# Altium schematic sheet Status_RGB.SchDoc
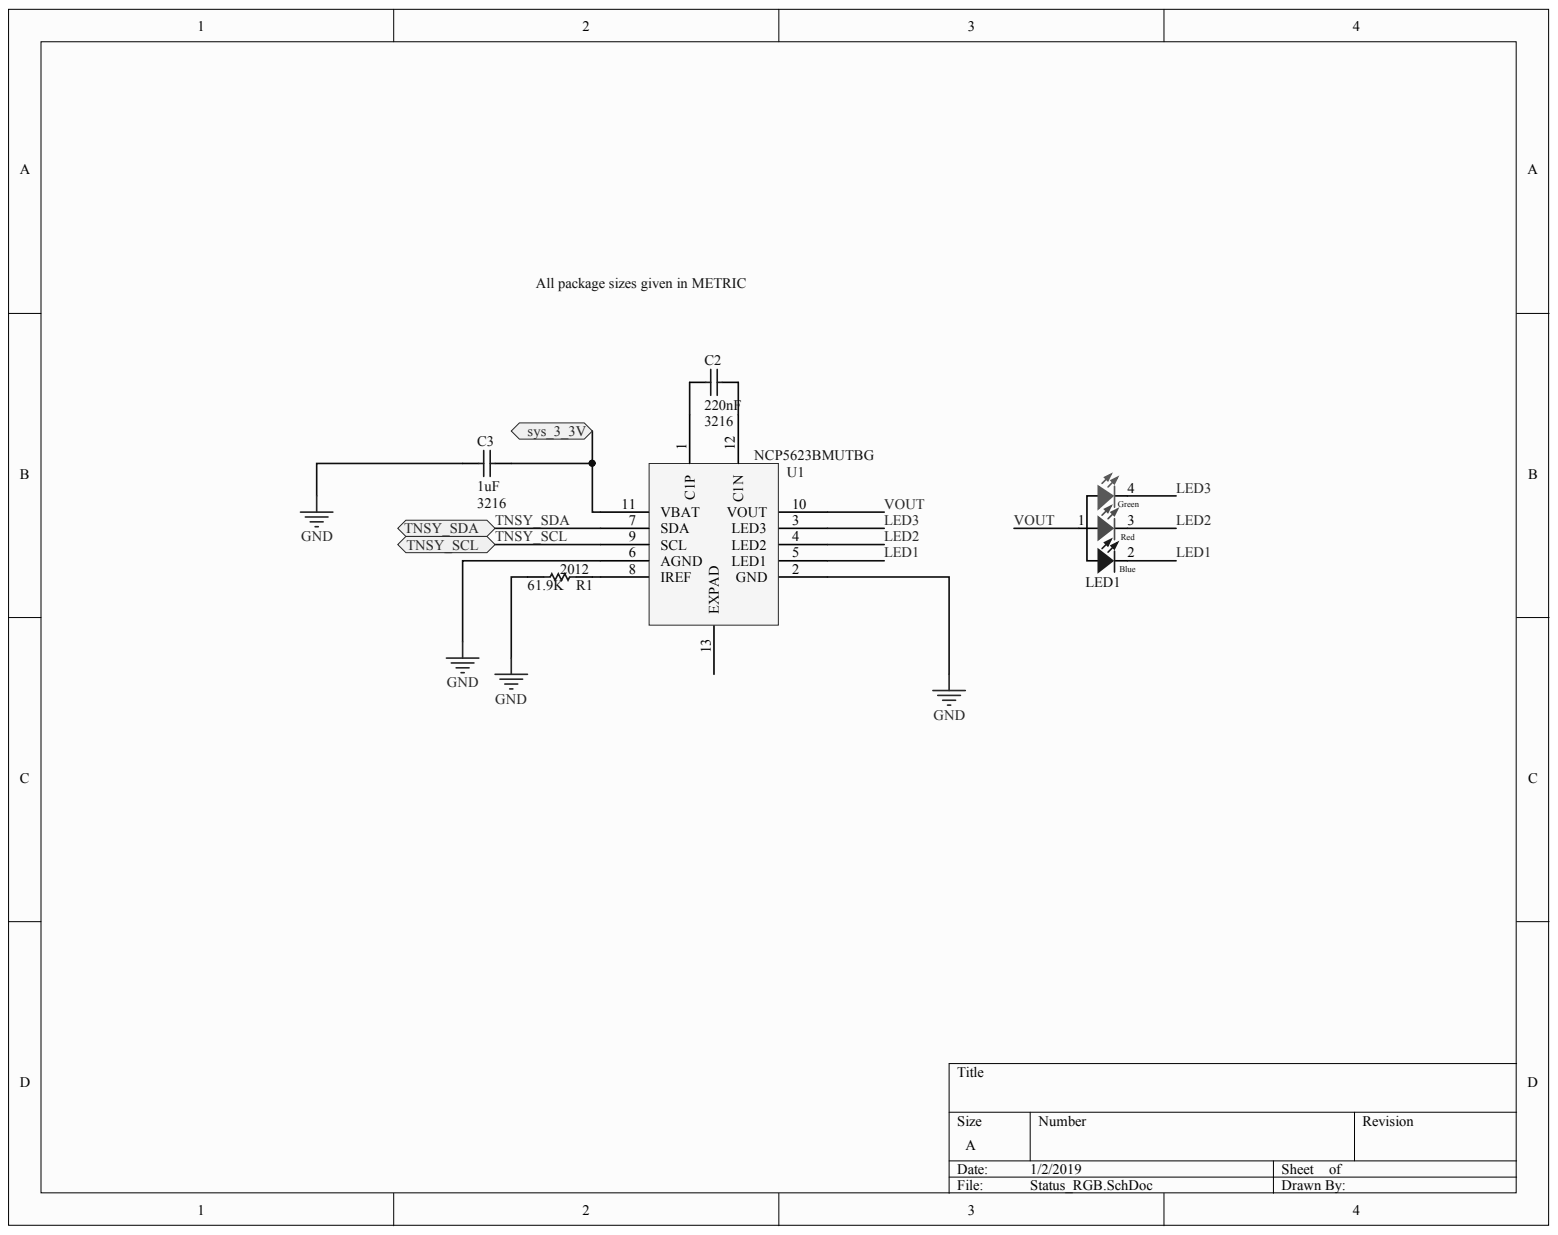
[diagram p0: Status_RGB.SchDoc]

## Parts
C2  Capacitance: 220nF  [CAPC3216X180X50NL20T25]  (hidden comment: Kemet C1206C225K4PACTU)
C3  Capacitance: 1uF  Case/Package (SI): 3216  [CAPC3216X180X50NL20T25]  (hidden comment: Kemet C1206C225K4PACTU)
LED1  Würth Elektronik 150141M173100  [PCB-12518653-1]  (value not drawn)
R1  Case/Package (SI): 2012  Resistance: 61.9K  [RESC2013X70X40ML20T20]  (hidden comment: Panasonic ERJ-6GEYJ273V)
U1  NCP5623BMUTBG  [PCB-d6365vlnibte3r128ju3-1]

## Ports
SYS_3_3V, TNSY_SCL, TNSY_SDA

## Nets
GND: C3.2 R1.1 U1.2(GND) U1.6(AGND)
LED1: LED1.2 U1.5(LED1)
LED2: LED1.3 U1.4(LED2)
LED3: LED1.4 U1.3(LED3)
TNSY_SCL: U1.9(SCL) ; port TNSY_SCL
TNSY_SDA: U1.7(SDA) ; port TNSY_SDA
VOUT: LED1.1 U1.10(VOUT)
NetC2_1: C2.1 U1.12(C1N)
NetC2_2: C2.2 U1.1(C1P)
NetC3_1: C3.1 U1.11(VBAT) ; port SYS_3_3V
NetR1_2: R1.2 U1.8(IREF)

Not connected: U1 13(EXPAD)

## Library data of the parts
C2 = CMP-124457-2: CAP CER 2.2UF 16V 10% X5R 1206; Case/Package (SI): 3216; Dielectric Material: Ceramic; Lead-Free Status: Lead Free; Voltage Rating (DC): 16.0 V; Capacitance Tolerance: ±10%; Case/Package: 1206; Component Kind: Standard; Dielectric Characteristic: X5R; Library Name: CommunityVault; Manufacturer Part Number: C1206C225K4PACTU; Mounting Style: Surface Mount; Number of Pins: 2; OctopartID: a5616c6acd317aa6; Octopart Url: https://octopart.com/c1206c225k4pactu-kemet-85302; Packaging: Cut Tape (CT); RoHS: Compliant; Size-Height: 0.0011 m; Size-Length: 0.0032 m; Size-Width: 0.0016 m
C3 = CMP-124457-2: CAP CER 2.2UF 16V 10% X5R 1206; Dielectric Material: Ceramic; Lead-Free Status: Lead Free; Voltage Rating (DC): 16.0 V; Capacitance Tolerance: ±10%; Case/Package: 1206; Component Kind: Standard; Dielectric Characteristic: X5R; Library Name: CommunityVault; Manufacturer Part Number: C1206C225K4PACTU; Mounting Style: Surface Mount; Number of Pins: 2; OctopartID: a5616c6acd317aa6; Octopart Url: https://octopart.com/c1206c225k4pactu-kemet-85302; Packaging: Cut Tape (CT); RoHS: Compliant; Size-Height: 0.0011 m; Size-Length: 0.0032 m; Size-Width: 0.0016 m
LED1 = CMP-12518653-9: SMD LED [Würth Elektronik] 150141M173100 SMD LED; Viewing Angle: 120 °; Component Kind: Standard; Library Name: CommunityVault; Manufacturer Part Number: 150141M173100; OctopartID: cdf605399db31231; Octopart URL: http://octopart.com/150141m173100-w%C3%BCrth+elektronik-29717795; REACH SVHC Compliance: No SVHC; RoHS: Compliant
R1 = CMP-3654967-2: Thick Film Resistors - SMD 0805 27Kohms 5% Tol; Lead-Free Status: Lead Free; Power Rating: 0.125 W; Voltage Rating (DC): 150.0 V; Case/Package: 0805; Component Kind: Standard; Library Name: CommunityVault; Lifecycle Status: Not Listed by Manufacturer; Manufacturer Part Number: ERJ-6GEYJ273V; Mounting Style: Surface Mount; OctopartID: b4da7e9df50f6b1d; Octopart Url: https://octopart.com/erj-6geyj273v-panasonic-55403699; Packaging: Cut Tape (CT); Resistance Tolerance: ±5%; RoHS: Compliant; Size-Height: 0.0006 m; Size-Length: 0.002 m; Size-Width: 0.00125 m
U1 = CMP-e7f92e30cfc2383e-5: NCP5623 Series 90 mA 5.5 V 1.2 kHz 3-Channel Charge Pump LED Driver - LLGA-12; Output Voltage: 5.50 V (max), 5.50 V; Component Kind: Standard; Halogen Free Status: Halogen Free; Library Name: CommunityVault; Manufacturer Part Number: NCP5623BMUTBG; OctopartID: e7f92e30cfc2383e; Octopart URL: https://octopart.com/ncp5623bmutbg-on+semiconductor-12782941
